# Altium schematic sheet GammaMRICAB_2 - Emission_2b.SchDoc
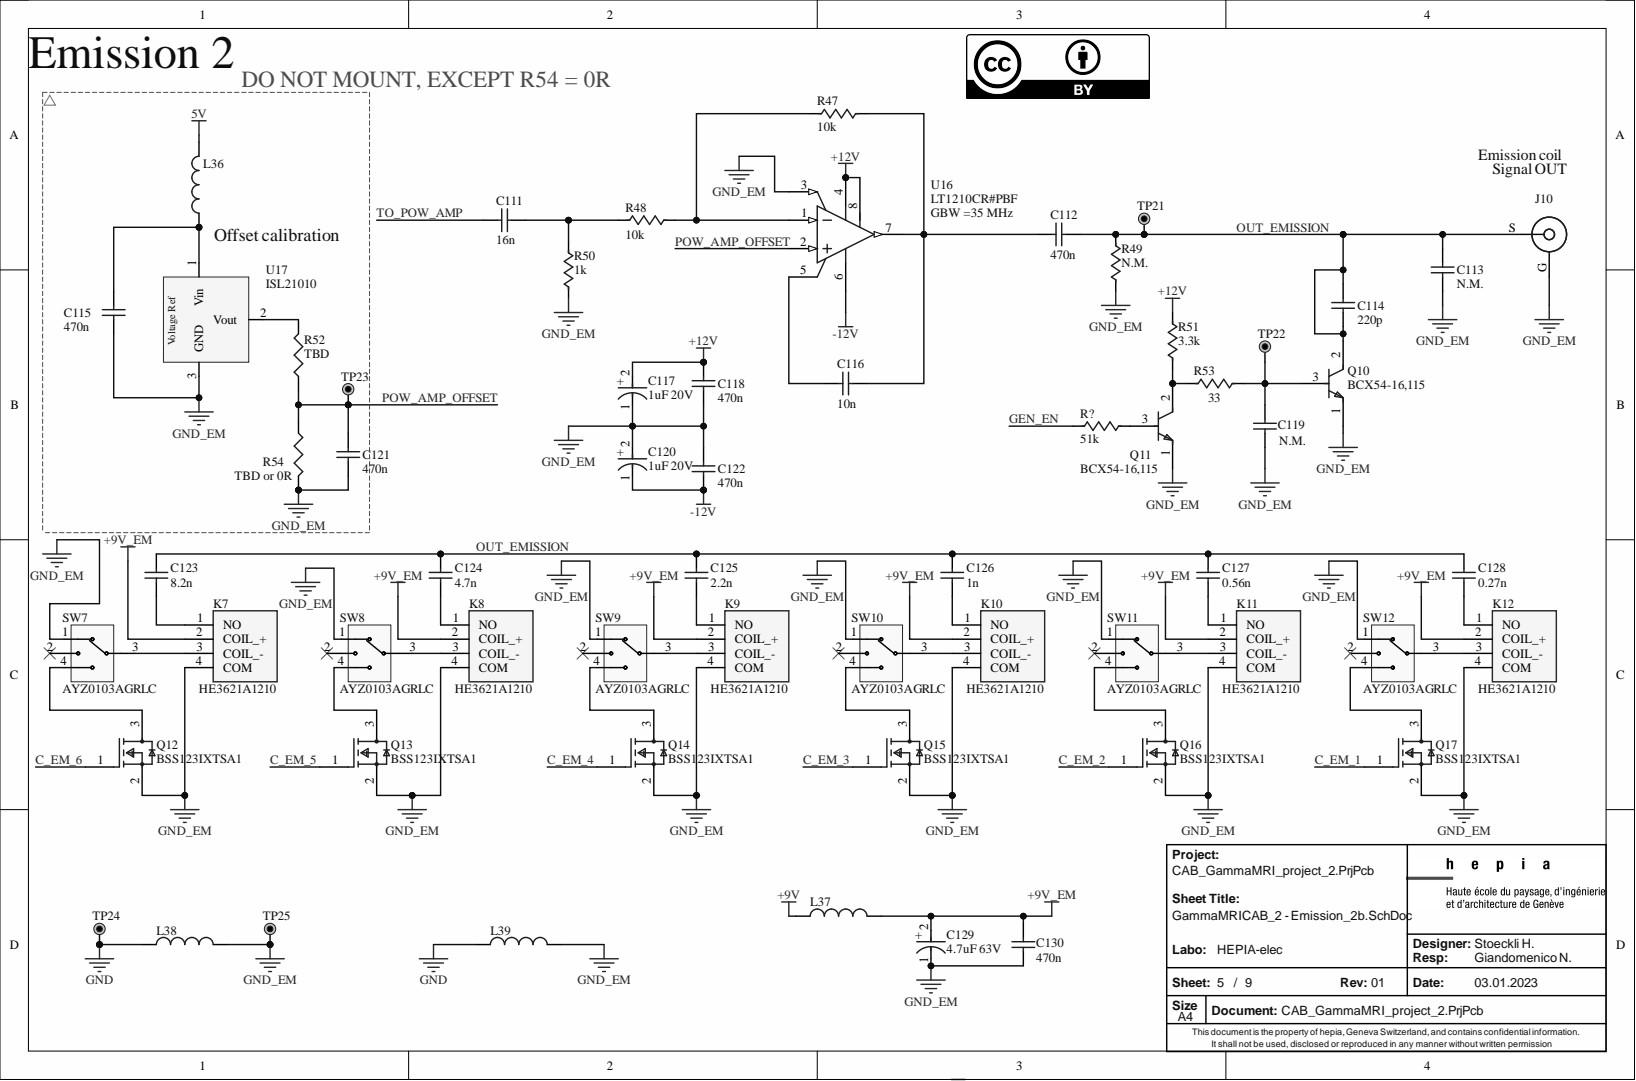
[diagram p0: GammaMRICAB_2 - Emission_2b.SchDoc]

## Parts
C111  16n  [1608[0603]]
C112  470n  [1608[0603]]
C113  N.M.  [1608[0603]]
C114  220p  [1608[0603]]
C115  470n  [0402]
C116  10n  [1608[0603]]
C117  1uF 20V  [FP-TAJA-MFG]
C118  470n  [1608[0603]]
C119  N.M.  [1608[0603]]
C120  1uF 20V  [FP-TAJA-MFG]
C121  470n  [0402]
C122  470n  [1608[0603]]
C123  8.2n  [1608[0603]]
C124  4.7n  [1608[0603]]
C125  2.2n  [1608[0603]]
C126  1n  [1608[0603]]
C127  0.56n  [1608[0603]]
C128  0.27n  [1608[0603]]
C129  4.7uF 63V  [FP-TCJC-MFG]
C130  470n  [1608[0603]]
J10  5227161-2  [TE_5227161-2]  (value not drawn)
K7  HE3621A1210  [HE3621A1210]
K8  HE3621A1210  [HE3621A1210]
K9  HE3621A1210  [HE3621A1210]
K10  HE3621A1210  [HE3621A1210]
K11  HE3621A1210  [HE3621A1210]
K12  HE3621A1210  [HE3621A1210]
L36  BKP0603HS121-T  [1608[0603]]  (value not drawn)
L37  BKP0603HS121-T  [1608[0603]]  (value not drawn)
L38  BKP0603HS121-T  [1608[0603]]  (value not drawn)
L39  BKP0603HS121-T  [1608[0603]]  (value not drawn)
Q10  BCX54-16,115  [FP-SOT89-MFG]
Q11  BCX54-16,115  [FP-SOT89-MFG]
Q12  BSS123IXTSA1  [FP-PG-SOT23-3-IPC_A]
Q13  BSS123IXTSA1  [FP-PG-SOT23-3-IPC_A]
Q14  BSS123IXTSA1  [FP-PG-SOT23-3-IPC_A]
Q15  BSS123IXTSA1  [FP-PG-SOT23-3-IPC_A]
Q16  BSS123IXTSA1  [FP-PG-SOT23-3-IPC_A]
Q17  BSS123IXTSA1  [FP-PG-SOT23-3-IPC_A]
R47  10k  [1608[0603]]
R48  10k  [1608[0603]]
R49  N.M.  [1608[0603]]
R50  1k  [1608[0603]]
R51  3.3k  [1608[0603]]
R52  TBD  [0402]
R53  33  [1608[0603]]
R54  TBD or 0R  [0402]
R?  51k  [1608[0603]]
SW7  AYZ0103AGRLC  [AYZ0103AGRLC]
SW8  AYZ0103AGRLC  [AYZ0103AGRLC]
SW9  AYZ0103AGRLC  [AYZ0103AGRLC]
SW10  AYZ0103AGRLC  [AYZ0103AGRLC]
SW11  AYZ0103AGRLC  [AYZ0103AGRLC]
SW12  AYZ0103AGRLC  [AYZ0103AGRLC]
TP21  TP_PAD_Hole  [TP_PAD_Hole]  (value not drawn)
TP22  TP_PAD_Hole  [TP_PAD_Hole]  (value not drawn)
TP23  TP_PAD_Hole  [TP_PAD_Hole]  (value not drawn)
TP24  TP_PAD_Hole  [TP_PAD_Hole]  (value not drawn)
TP25  TP_PAD_Hole  [TP_PAD_Hole]  (value not drawn)
U16  LT1210CR#PBF  [LT-R-7_M]
U17  ISL21010  [SOT23-3]

## Nets
5V: L36.2
+9V: L37.1
+9V_EM: C129.2 C130.2 K7.2(COIL_+) K8.2(COIL_+) K9.2(COIL_+) K10.2(COIL_+) K11.2(COIL_+) K12.2(COIL_+) L37.2
+12V: C117.2 C118.2 R51.1 U16.4 U16.8
-12V: C120.1 C122.1 U16.6
GND: L38.1 L39.1 TP24.1
GND_EM: C113.1 C115.1 C117.1 C118.1 C119.1 C120.2 C121.1 C122.2 C129.1 C130.1 J10.G K7.4(COM) K8.4(COM) K9.4(COM) K10.4(COM) K11.4(COM) K12.4(COM) L38.2 L39.2 Q10.1 Q11.1 Q12.2 Q13.2 Q14.2 Q15.2 Q16.2 Q17.2 R49.2 R50.1 R54.2 SW7.1 SW8.1 SW9.1 SW10.1 SW11.1 SW12.1 TP25.1 U16.3 U17.3
C_EM_1: Q17.1
C_EM_2: Q16.1
C_EM_3: Q15.1
C_EM_4: Q14.1
C_EM_5: Q13.1
C_EM_6: Q12.1
GEN_EN: R?.2
OUT_EMISSION: C112.1 C113.2 C114.1 C114.2 C123.2 C124.2 C125.2 C126.2 C127.2 C128.2 J10.S Q10.2 R49.1 TP21.1
POW_AMP_OFFSET: C121.2 R52.1 R54.1 TP23.1 U16.2
TO_POW_AMP: C111.2
NetC111_1: C111.1 R48.1 R50.2
NetC112_2: C112.2 C116.1 R47.2 U16.7
NetC115_2: C115.2 L36.1 U17.1
NetC116_2: C116.2 U16.5
NetC119_2: C119.2 Q10.3 R53.2 TP22.1
NetC123_1: C123.1 K7.1(NO)
NetC124_1: C124.1 K8.1(NO)
NetC125_1: C125.1 K9.1(NO)
NetC126_1: C126.1 K10.1(NO)
NetC127_1: C127.1 K11.1(NO)
NetC128_1: C128.1 K12.1(NO)
NetK7_3: K7.3(COIL_-) SW7.3
NetK8_3: K8.3(COIL_-) SW8.3
NetK9_3: K9.3(COIL_-) SW9.3
NetK10_3: K10.3(COIL_-) SW10.3
NetK11_3: K11.3(COIL_-) SW11.3
NetK12_3: K12.3(COIL_-) SW12.3
NetQ11_2: Q11.2 R51.2 R53.1
NetQ11_3: Q11.3 R?.1
NetQ12_3: Q12.3 SW7.4
NetQ13_3: Q13.3 SW8.4
NetQ14_3: Q14.3 SW9.4
NetQ15_3: Q15.3 SW10.4
NetQ16_3: Q16.3 SW11.4
NetQ17_3: Q17.3 SW12.4
NetR47_1: R47.1 R48.2 U16.1
NetR52_2: R52.2 U17.2

Not connected: SW7 2; SW8 2; SW9 2; SW10 2; SW11 2; SW12 2

## Library data of the parts
C111 = Cap: Capacitor 16nF C0603 X6S 50V; Component Kind: Standard; Library Name: Miscellaneous Devices.IntLib; Signal Integrity: Cap; Simulation: CAP; Supplier1: Digikey
C112 = Cap: Capacitor 330p C0603 X6S 50V; Component Kind: Standard; Library Name: Miscellaneous Devices.IntLib; Signal Integrity: Cap; Simulation: CAP; Supplier1: Digikey
C113 = Cap: Capacitor 3.3nF C0603 X6S 50V; Component Kind: Standard; Library Name: Miscellaneous Devices.IntLib; Signal Integrity: Cap; Simulation: CAP; Supplier1: Digikey
C114 = Cap: Capacitor 220pF C0603 X6S 50V; Component Kind: Standard; Library Name: Miscellaneous Devices.IntLib; Signal Integrity: Cap; Simulation: CAP; Supplier1: Digikey
C115 C121 = Cap: Capacitor 470nF C0402 X5R 6.3V; Component Kind: Standard; Library Name: Miscellaneous Devices.IntLib; Signal Integrity: Cap; Simulation: CAP; Supplier1: Digikey; Supplier1PN1: 311-1684-6-ND
C116 = Cap: Capacitor 10nF C0603 X6S 25V; Component Kind: Standard; Library Name: Miscellaneous Devices.IntLib; Signal Integrity: Cap; Simulation: CAP; Supplier1: Digikey
C117 C120 = CMP-2000-07216-2: TAJA105K020RNJ 1uF 20V  ±10% 9Ω 1206  SMD Tantalum Capacitor; Component Kind: Standard; Library Name: Altium Content Vault; Supplier1: Digikey; Supplier1PN1: 478-1650-1-ND
C118 C122 C130 = Cap: Capacitor 470nF C0603 X6S 50V; Component Kind: Standard; Library Name: Miscellaneous Devices.IntLib; Signal Integrity: Cap; Simulation: CAP; Supplier1: Digikey
C119 = Cap: CAP CER 1nF 50V Y5V 0603; Component Kind: Standard; Library Name: Miscellaneous Devices.IntLib; Signal Integrity: Cap; Simulation: CAP; Supplier1: Digikey
C123 = Cap: Capacitor 8.2nF C0603 X6S 25V; Component Kind: Standard; Library Name: Miscellaneous Devices.IntLib; Signal Integrity: Cap; Simulation: CAP; Supplier1: Digikey
C124 = Cap: Capacitor 4.7nF C0603 X6S 25V; Component Kind: Standard; Library Name: Miscellaneous Devices.IntLib; Signal Integrity: Cap; Simulation: CAP; Supplier1: Digikey
C125 = Cap: Capacitor 2.2nF C0603 X6S 25V; Component Kind: Standard; Library Name: Miscellaneous Devices.IntLib; Signal Integrity: Cap; Simulation: CAP; Supplier1: Digikey
C126 = Cap: Capacitor 1nF C0603 X6S 25V; Component Kind: Standard; Library Name: Miscellaneous Devices.IntLib; Signal Integrity: Cap; Simulation: CAP; Supplier1: Digikey
C127 = Cap: Capacitor 0.56nF C0603 X6S 25V; Component Kind: Standard; Library Name: Miscellaneous Devices.IntLib; Signal Integrity: Cap; Simulation: CAP; Supplier1: Digikey
C128 = Cap: Capacitor 0.27nF C0603 X6S 25V; Component Kind: Standard; Library Name: Miscellaneous Devices.IntLib; Signal Integrity: Cap; Simulation: CAP; Supplier1: Digikey
C129 = CMP-04423-000110-1: 4.7uF 63V  ±20% 200mΩ 2312  SMD Polymer Capacitor TCJC475M063R0200E; Component Kind: Standard; Library Name: Altium Content Vault; Supplier1: Digikey; Supplier1PN1: TCJC475M063R0200E
J10 = 5227161-2: Jack, PCB; 50 Ohms; Right Angle; BNC; Gold (30); Panel; Jack; Valox; Phosphor Bronze; Component Kind: Standard; Supplier1: Digikey; Supplier1PN1: A32245-ND; Library Name: CAB_SCH_LIB.SchLib
K7-K12 = HE3621A1210: Relay or Contactor; Component Kind: Standard; Supplier1: Digikey; Supplier1PN1: HE209-ND; Library Name: CAB_SCH_LIB.SchLib
L36-L39 = Inductor: FERRITE BEAD 120 OHM 0603(1608) 1LN; Component Kind: Standard; Library Name: Miscellaneous Devices.IntLib; Signal Integrity: Inductor; Simulation: INDUCTOR; Supplier1: Digikey; Supplier1PN1: 553-2387-1-ND
Q10 Q11 = CMP-14406-000006-2: TRANS NPN 45V 1A SOT89; Component Kind: Standard; Library Name: Altium Content Vault; RoHS Compliant: Yes
Q12-Q17 = BSS123IXTSA1: SMALL SIGNAL MOSFETS PG-SOT23-3; Component Kind: Standard; Supplier1: Digikey; Supplier1PN1: 448-BSS123IXTSA1DKR-ND; Library Name: CAB_SCH_LIB.SchLib
R47 = D_RES-116: Thick Film Resistor, Boite Labo 0603, 10k 1%; Database Table Name: D_Resistors$; Component Kind: Standard; Supplier1: Mouser; Library Name: Database_Altium_Hepia_Elec.DbLib
R48 = D_RES-108: Thick Film Resistor, Boite Labo 0603, 10k 1%; Database Table Name: D_Resistors$; Component Kind: Standard; Supplier1: Mouser; Library Name: Database_Altium_Hepia_Elec.DbLib
R49 R51 = D_RES-120: Thick Film Resistor, Boite Labo 0603, 3.3k 1%; Database Table Name: D_Resistors$; Component Kind: Standard; Supplier1: Digikey; Library Name: Database_Altium_Hepia_Elec.DbLib
R50 = D_RES-108: Thick Film Resistor, Boite Labo 0603, 1k 1%; Database Table Name: D_Resistors$; Component Kind: Standard; Supplier1: Mouser; Library Name: Database_Altium_Hepia_Elec.DbLib
R52 = Res3: Resistor 200kOhm 1% 0402; Component Kind: Standard; Library Name: Miscellaneous Devices.IntLib; Signal Integrity: Res; Simulation: RESISTOR; Supplier1: Digikey; Supplier1PN1: P200KLCT-ND
R53 = D_RES-120: Thick Film Resistor, Boite Labo 0603, 33R 1%; Database Table Name: D_Resistors$; Component Kind: Standard; Supplier1: Digikey; Library Name: Database_Altium_Hepia_Elec.DbLib
R54 = Res3: Resistor 1kOhm 1% 0402; Component Kind: Standard; Library Name: Miscellaneous Devices.IntLib; Signal Integrity: Res; Simulation: RESISTOR; Supplier1: Digikey; Supplier1PN1: 311-1.00KLRCT-ND
R? = D_RES-108: Thick Film Resistor, Boite Labo 0603, 51k 1%; Database Table Name: D_Resistors$; Component Kind: Standard; Supplier1: Mouser; Library Name: Database_Altium_Hepia_Elec.DbLib
SW7-SW12 = AYZ0103AGRLC: No Description Available; Component Kind: Standard; Supplier1: Digikey; Supplier1PN1: 401-2014-1-ND; Library Name: CAB_SCH_LIB.SchLib
U16 = LT1210: Single Channel, Operational Amplifier, 1 A Output Current, 66 MHz Typical GBW, 900 V/us Typical SR, 8 to 36 V, 7-Pin DPAK (R-7), Commercial, Pb-Free; Component Kind: Standard; Supplier1: Digikey; Supplier1PN1: LT1210CR#TRPBFCT-ND; Library Name: CAB_SCH_LIB.SchLib
U17 = ISL21010: Micropower voltage reference, 1.5V, SOT-23-3; Component Kind: Standard; Supplier1: Digikey; Supplier1PN1: ISL21080CIH315Z-TKCT-ND; Library Name: CAB_SCH_LIB.SchLib
TP21-TP25 = TP_PAD_Hole: Component Kind: Standard; Library Name: CAB_SCH_LIB.SchLib
